# Altium schematic sheet 4  - Latching.SchDoc("4  - Latching")
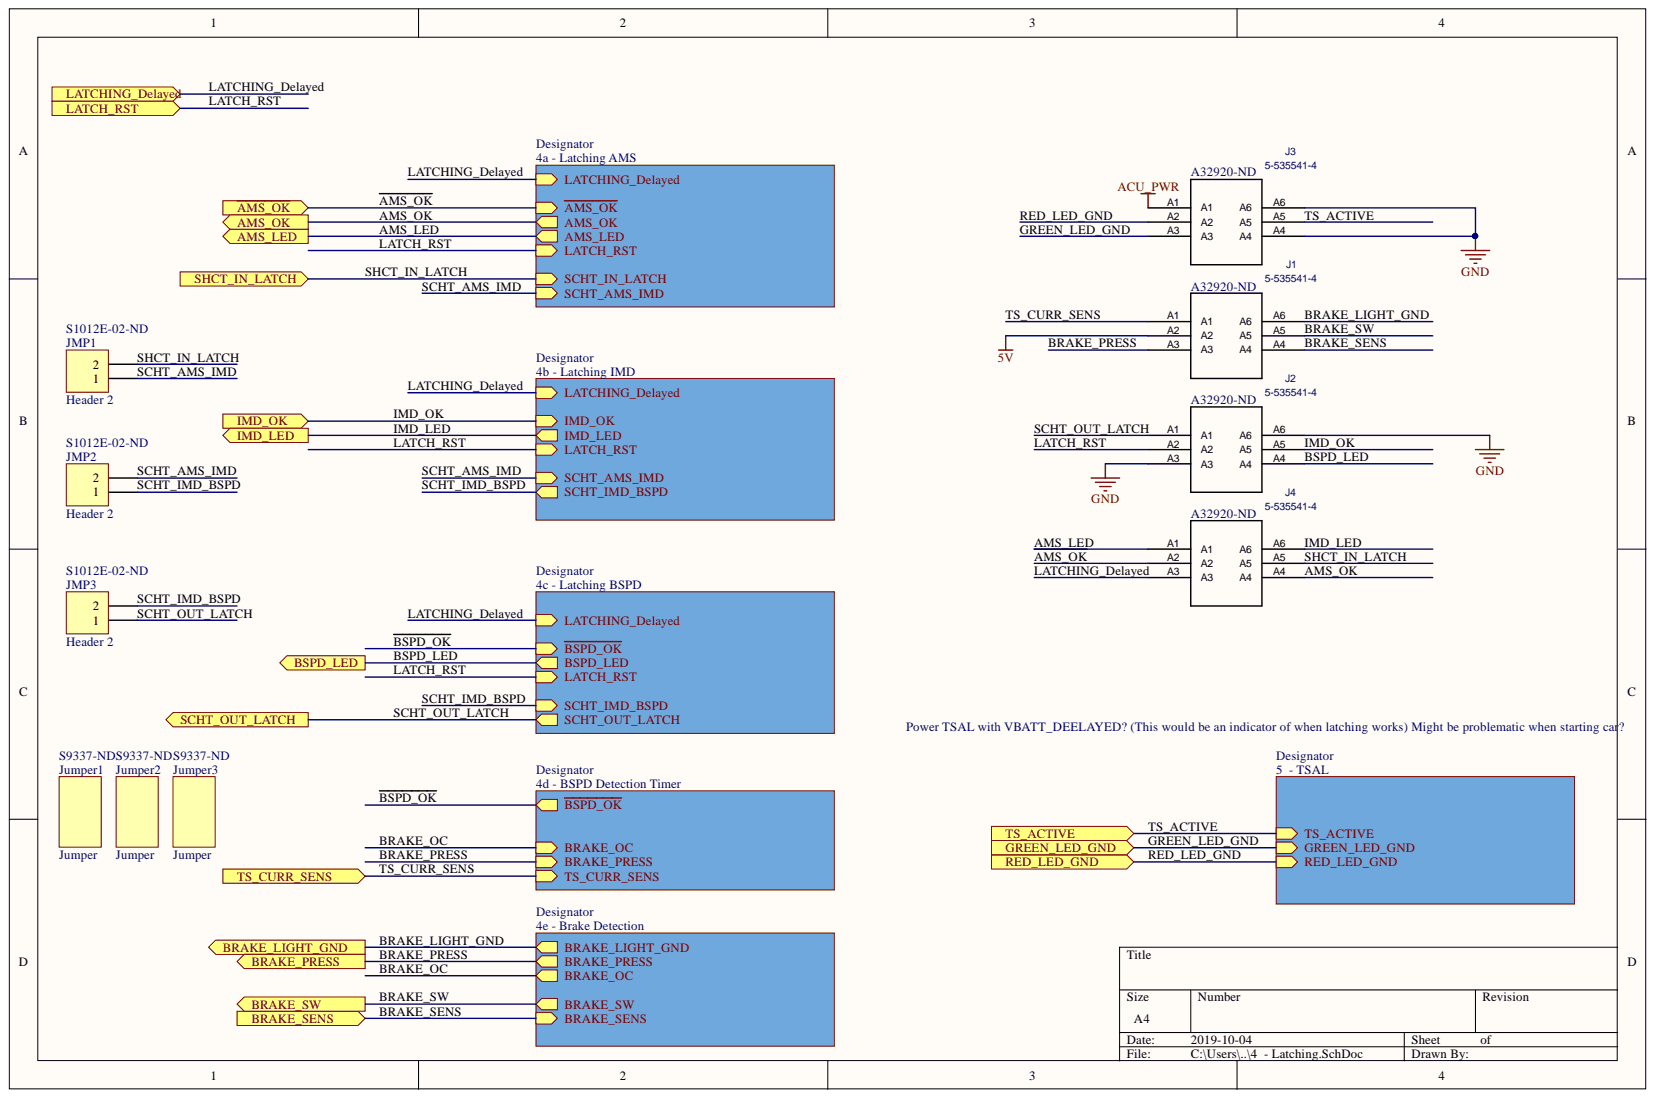
[diagram p0: 4  - Latching.SchDoc]

## Parts
J1  5-535541-4  Supplier Part Number 1: A32920-ND  [5-535541-4_5-535541-4(Primary)]
J2  5-535541-4  Supplier Part Number 1: A32920-ND  [5-535541-4_5-535541-4(Primary)]
J3  5-535541-4  Supplier Part Number 1: A32920-ND  [5-535541-4_5-535541-4(Primary)]
J4  5-535541-4  Supplier Part Number 1: A32920-ND  [5-535541-4_5-535541-4(Primary)]
JMP1  Header 2  Supplier Part Number 1: S1012E-02-ND  [2Pin Jumper]
JMP2  Header 2  Supplier Part Number 1: S1012E-02-ND  [2Pin Jumper]
JMP3  Header 2  Supplier Part Number 1: S1012E-02-ND  [2Pin Jumper]
Jumper1  Jumper  Supplier Part Number 1: S9337-ND
Jumper2  Jumper  Supplier Part Number 1: S9337-ND
Jumper3  Jumper  Supplier Part Number 1: S9337-ND

## Ports
AMS_LED, AMS_OK, BRAKE_LIGHT_GND, BRAKE_PRESS, BRAKE_SENS, BRAKE_SW, BSPD_LED, GREEN_LED_GND, IMD_LED, IMD_OK, LATCH_RST, LATCHING_Delayed, RED_LED_GND, SCHT_OUT_LATCH, SHCT_IN_LATCH, TS_ACTIVE, TS_CURR_SENS, ~{AMS_OK}

## Nets
5V: J1.A2
ACU_PWR: J3.A1
GND: J2.A3 J2.A6 J3.A4 J3.A6
~{AMS_OK}: J4.A2 ; port ~{AMS_OK}
AMS_LED: J4.A1 ; port AMS_LED
AMS_OK: J4.A4 ; port AMS_OK
BRAKE_LIGHT_GND: J1.A6 ; port BRAKE_LIGHT_GND
BRAKE_PRESS: J1.A3 ; port BRAKE_PRESS
BRAKE_SENS: J1.A4 ; port BRAKE_SENS
BRAKE_SW: J1.A5 ; port BRAKE_SW
BSPD_LED: J2.A4 ; port BSPD_LED
GREEN_LED_GND: J3.A3 ; port GREEN_LED_GND
IMD_LED: J4.A6 ; port IMD_LED
IMD_OK: J2.A5 ; port IMD_OK
LATCH_RST: J2.A2 ; port LATCH_RST
LATCHING_Delayed: J4.A3 ; port LATCHING_Delayed
RED_LED_GND: J3.A2 ; port RED_LED_GND
SCHT_AMS_IMD: JMP1.1 JMP2.2
SCHT_IMD_BSPD: JMP2.1 JMP3.2
SCHT_OUT_LATCH: J2.A1 JMP3.1 ; port SCHT_OUT_LATCH
SHCT_IN_LATCH: J4.A5 JMP1.2 ; port SHCT_IN_LATCH
TS_ACTIVE: J3.A5 ; port TS_ACTIVE
TS_CURR_SENS: J1.A1 ; port TS_CURR_SENS
~{BSPD_OK}:
BRAKE_OC:

## Library data of the parts
J1-J4 = 5-535541-4: Vendor: TE Connectivity; C: Copyright (C) 2015 Accelerated Designs. All rights reserved; Built_By: EMA_UL_Team; Value: 5-535541-4; Supplier 1: Digi-Key; Manufacturer_Part_Number: 5-535541-4; Component Kind: Standard; Library Name: ACB_lib.SchLib
JMP1-JMP3 = Header 2: Header, 2-Pin; Manufacturer Part Number: M20-9990246; Manufacturer: Harwin Inc.; Style: Board to Board or Cable; Shrouding: Unshrouded; Pitch - Mating: 0.100" (2.54mm); Overall Contact Length: 0.460" (11.68mm); Number of Positions Loaded: All; Number of Positions: 2; Material Flammability Rating: UL94 V-0; Mated Stacking Heights: 10.04mm, 10.64mm, 11.04mm, 16.54mm; Current Rating: 3A per Contact; Contact Length - Post: 0.118" (3.00mm); Contact Length - Mating: 0.240" (6.10mm); Connector Type: Header, Cuttable; Voltage Rating: -; Termination: Solder; Supplier 1: Digi-Key; Row Spacing - Mating: -; RoHS: RoHS Compliant; Part Status: Active; Packaging: Bulk; Operating Temperature: -40°C ~ 105°C; Number of Rows: 1; Mounting Type: Through Hole; Library Name: Miscellaneous Connectors.IntLib; LatestRevisionNote: Re-released for DXP Platform.; LatestRevisionDate: 17-Jul-2002; Insulation Material: Polyamide (PA6T), Nylon 6T; Insulation Height: 0.100" (2.54mm); Insulation Color: Black; Ingress Protection: -; Features: -; Fastening Type: Push-Pull; Contact Type: Male Pin; Contact Shape: Square; Contact Material: Brass; Contact Finish Thickness - Post: -; Contact Finish Thickness - Mating: -; Contact Finish - Post: Tin; Contact Finish - Mating: Tin; ComponentLink1URL: https://cdn.harwin.com/pdfs/M20-999.pdf; ComponentLink1Description: https://cdn.harwin.com/pdfs/M20-999.pdf; Component Kind: Standard; Category: Connectors, Interconnects; Applications: -; Publisher: Altium Limited
Jumper1-Jumper3 = Jumper: Supplier 1: Digi-Key; Component Kind: Standard; Library Name: BRAKE TEMP Components.SchLib
